# Altium schematic sheet 3_PressureSensor.SchDoc(3_PressureSensor)
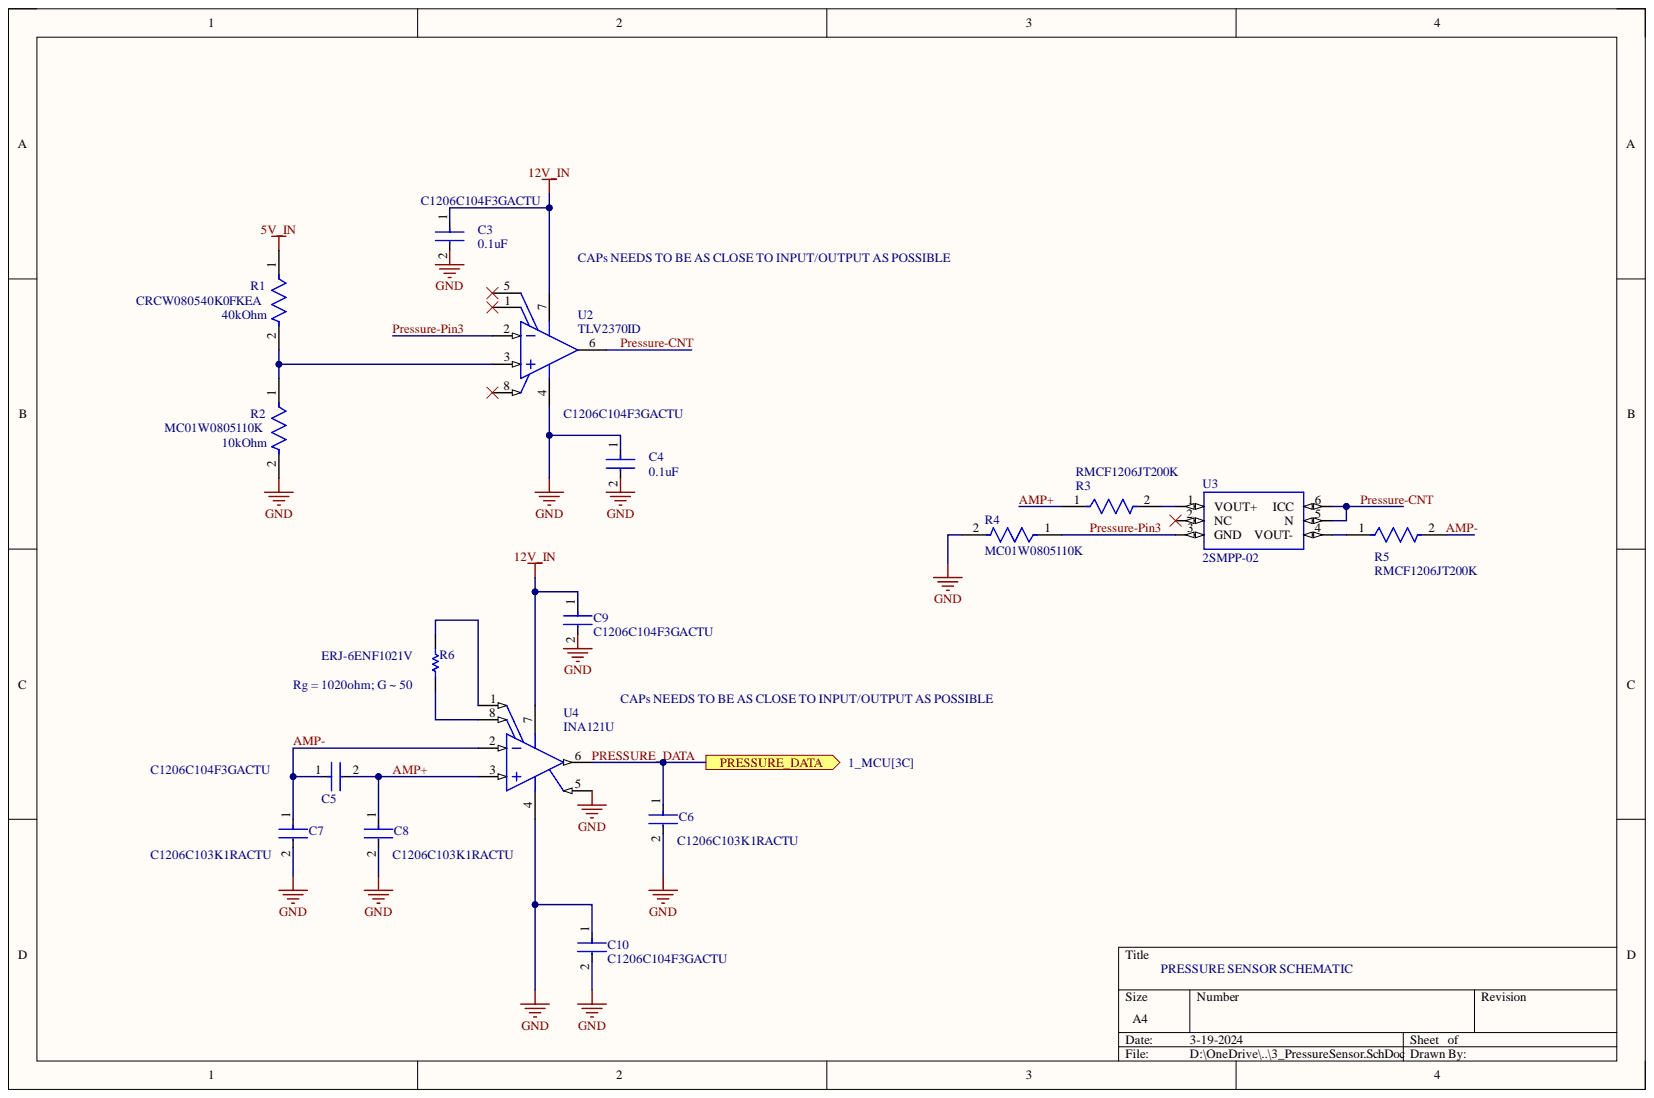
[diagram p0: 3_PressureSensor.SchDoc]

## Parts
C3  C1206C104F3GACTU  [FP-C1206C-EB-MFG]
C4  C1206C104F3GACTU  [FP-C1206C-EB-MFG]
C5  C1206C104F3GACTU  [FP-C1206C-EB-MFG]
C6  C1206C103K1RACTU  [FP-C1206C-EB-MFG]
C7  C1206C103K1RACTU  [FP-C1206C-EB-MFG]
C8  C1206C103K1RACTU  [FP-C1206C-EB-MFG]
C9  C1206C104F3GACTU  [FP-C1206C-EB-MFG]
C10  C1206C104F3GACTU  [FP-C1206C-EB-MFG]
R1  CRCW080540K0FKEA  [FP-CRCW0805-e3-MFG]
R2  MC01W0805110K  [FP-MC01W0805-IPC_C]
R3  RMCF1206JT200K  [FP-RMCF1206-IPC_C]
R4  MC01W0805110K  [FP-MC01W0805-IPC_C]
R5  RMCF1206JT200K  [FP-RMCF1206-IPC_C]
R6  ERJ-6ENF1021V  [RESC2013X70X40ML20T20]
U2  TLV2370ID  [D0008A_L]
U3  2SMPP-02  [2SMPP_PAC]
U4  INA121U  [D0008A_M]

## Ports
PRESSURE_DATA

## Nets
5V_IN: R1.1
12V_IN: C3.1 C9.1 U2.7 U4.7
GND: C3.2 C4.1 C4.2 C6.2 C7.2 C8.2 C9.2 C10.1 C10.2 R2.2 R4.2 U2.4 U4.4 U4.5
AMP+: C5.2 C8.1 R3.1 U4.3
AMP-: C5.1 C7.1 R5.2 U4.2
Pressure-CNT: U2.6 U3.5(N) U3.6(ICC)
Pressure-Pin3: R4.1 U2.2 U3.3(GND)
PRESSURE_DATA: C6.1 U4.6 ; port PRESSURE_DATA
NetR1_2: R1.2 R2.1 U2.3
NetR3_2: R3.2 U3.1(VOUT+)
NetR5_1: R5.1 U3.4(VOUT-)
NetR6_1: R6.1 U4.1
NetR6_2: R6.2 U4.8

Not connected: U2 1 5 8; U3 2(NC)

## Library data of the parts
C3-C5 C9 C10 = CMP-1037-04186-2: CAP CER 0.1UF 25V C0G/NP0 1206; Manufacturer: KEMET; Automotive Grade: Grade 0; Capacitance: 0.10 μF; Material: Ceramic; Temperature Characteristic: C0G; Temperature Coefficient: 15%; Tolerance: 0.01; Value: 0.10 μF; Automotive: Yes; Category: Cap; Component Kind: Standard; ComponentLink2Description: Datasheet; ComponentLink2URL: https://content.kemet.com/datasheets/KEM_C1007_X8R_ULTRA_150C_SMD.pdf; Device Class L1: Passive Components; Device Class L2: Capacitors; Device Class L3: Ceramic Capacitors; Digikey Description: CAP CER 0.1UF 25V C0G/NP0 1206; Height: 0.88mm; Ipc Land Pattern Name: CAPC320160X78; Lead Free: Yes; Library Name: Altium Content Vault; Manufacturer Part Number: C1206C104F3GACTU; Mouser Description: Multilayer Ceramic Capacitors MLCC - SMD/SMT 25volts 0.1uF 1% C0G; Octopart: https://octopart.com/c1206c104f3gactu-kemet-13149870; Package: C1206C-EB; RoHS: TRUE; Temperature: -55°C to +150°C; Temperature Range High: +150°C; Temperature Range Low: -55°C; Voltage Rating: 25 V
C6-C8 = CMP-03020-021723-1: Cap Ceramic 0.01uF 100V X7R 10% SMD 1206 125°C Plastic T/R; Manufacturer: KEMET; Automotive Grade: Grade 0; Capacitance: 10,000 pF; Temperature Coefficient: 15%; Tolerance: 0.1; Value: 10,000 pF; Automotive: Yes; Category: Cap; Component Kind: Standard; ComponentLink2Description: Datasheet; ComponentLink2URL: https://content.kemet.com/datasheets/KEM_C1007_X8R_ULTRA_150C_SMD.pdf; Device Class L1: Passive Components; Device Class L2: Capacitors; Device Class L3: Ceramic Capacitors; Digikey Description: Cap Ceramic 0.01uF 100V X7R 10% SMD 1206 125°C Plastic T/R; Height: 0.88mm; Ipc Land Pattern Name: CAPC320160X78; Lead Free: Yes; Library Name: Altium Content Vault; Manufacturer Part Number: C1206C103K1RACTU; Material: Ceramic; Mouser Description: Multilayer Ceramic Capacitors MLCC - SMD/SMT 100volts 10000pF X7R 10%; Octopart: https://octopart.com/c1206c103k1ractu-kemet-117927; Package: C1206C-EB; RoHS: TRUE; Temperature: -55°C to +150°C; Temperature Characteristic: X7R; Temperature Range High: +150°C; Temperature Range Low: -55°C; Voltage Rating: 100 V
R1 = CMP-02407-003498-1: RES Thick Film, 40kΩ, 1%, 0.125W, 100ppm/°C, 0805; Max Power Dissipation: 125mW; Resistance: 40kR; Case/Package: 0805; Component Kind: Standard; Composition: Thick Film; Features: Automotive AEC-Q200; Height: 0.02inch; Lead Free: Lead Free; Length: 0.079inch; Library Name: Altium Content Vault; Max Operating Temperature: 155°C; Min Operating Temperature: -55°C; Number of Terminations: 2; Package Quantity: 5000; Packaging: Tape and Reel; Temperature Coefficient: 100ppm/°C; Tolerance: 1%; Width: 0.049inch
R2 R4 = CMP-12256-000003-1: Resistor, 10K, 0.1W, 1%, 0805, Reel; Power Rating: 100mW; Resistance: 10kR; Temperature Coefficient: 100ppm/°C; Voltage Rating: 150V; Case Code (Imperial): 0805; Case Code (Metric): 2012; Case/Package: 0805; Component Kind: Standard; Composition: Thick Film; Library Name: Altium Content Vault; Max Operating Temperature: 155°C; Min Operating Temperature: -55°C; Number of Pins: 2; Packaging: Cut Tape; REACH SVHC: No SVHC; Tolerance: 1%; Voltage Rating (DC): 150V; Width: 1.25mm
R3 R5 = CMP-26527-005036-1: 200kΩ ±5% 0.25W 1206 Thick Film Chip Resistor AEC-Q200 compliant; Max Power Dissipation: 250mW; Resistance: 200kR; Temperature Coefficient: 200ppm/°C; Case Code (Imperial): 1206; Case Code (Metric): 3216; Case/Package: 1206; Component Kind: Standard; Construction: Rectangular; Contact Plating: Tin; Depth: 1.6mm; Features: Automotive AEC-Q200; Height: 0.028inch; Lead Free: Lead Free; Length: 0.126inch; Library Name: Altium Content Vault; Max Operating Temperature: 155°C; Military Standard: Not; Min Operating Temperature: -55°C; Mount: Surface Mount; Number of Terminations: 2; Packaging: Tape and Reel; Power Rating: 250mW; Radiation Hardening: No; Resistor Type: Thick Film; RoHS Compliant: Yes; Series: RMCF; Size Code: 1206; Tolerance: 5%; Width: 0.063inch
R6 = CMP-2001-04380-1: Manufacturer: Panasonic; Component Kind: Standard; Library Name: Altium Content Vault; Part Number: ERJ-6ENF1021V
U2 = CMP-0272-03086-3: 550 uA/Ch, 3 MHz, RRIO Operational Amplifier with Shutdown, 2.7 to 16 V, -40 to 125 degC, 8-pin SOIC (D8), Green (RoHS & no Sb/Br); Manufacturer: Texas Instruments; CMRR(Min)(dB): 50; GBW(Typ)(MHz): 3; IIB(Max)(pA): 60; Iq per channel(Max)(mA): 0.9; Number of Channels: 1; Offset Drift(Typ)(uV/C): 2; Packing: TUBE; Rating: Catalog; Slew Rate(Typ)(V/us): 2.4; Sub Family: Low Supply Voltage Amplifier (<=2.7V), Precision Amplifier; Total Supply Voltage(Max)(+5V is 5, +/-5V is 10): 16; Total Supply Voltage(Min)(+5V is 5, +/-5V is 10): 2.7; Vio (25C)(Max)(mV): 4.5; Code_JEDEC: MS-012; Component Kind: Standard; ComponentLink1Description: Manufacturer URL; ComponentLink1URL: http://www.ti.com/; ComponentLink2Description: Datasheet; ComponentLink2URL: http://www.ti.com/lit/ds/symlink/tlv2370.pdf; ComponentLink3Description: Package Specification; ComponentLink3URL: http://www.ti.com/litv/pdf/msoi002j; DatasheetVersion: SLOS270D; Eco-Plan: Green (RoHS & no Sb/Br); Library Name: Altium Content Vault; Mounting Technology: Surface Mount; PackageDescription: 8-Pin Plastic Small Outline, Body 4.9 x 3.9 mm, Pitch 1.27 mm; PackageReference: D0008A; PackageVersion: 4040047-3/M, 06/2011; PartNumber: TLV2370ID
U3 = CMP-007-000000-1: Pressure Sensor 5.37PSI _37kPa_ Vented Gauge Male - 0.1 _2.59mm_ Tube 0 mV ~ 31 mV 6-SMD Module; MF: Omron; Availability: Unavailable; Component Kind: Standard; Component Type: Standard; Design Item ID: 2SMPP-02; Library Name: McMaster University; MP: 2SMPP-02; Package: SMD-6 Omron; Pin Count: 6; Price: None; SnapEDA_Link: https://www.snapeda.com/parts/2SMPP-02/Omron%20Electronics%20Inc-EMC%20Div/view-part/384453/?ref=snap; Source: SnapEDAsch.SchLib
U4 = CMP-0992-00043-2: FET-Input, Low Power Instrumentation Amplifier, -40 to 85 degC, 8-pin SOIC (D8), Green (RoHS & no Sb/Br); Manufacturer: Texas Instruments; CMRR(Min)(dB): 96; Gain(V/V): 1 to 10000; Input Bias Current (+/-)(Max)(nA): 0.05; Input Offset (+/-)(Max)(uV): 500; Input Offset Drift (+/-)(Max)(uV/Degrees Celsius): 5; Noise at 1kHz(Typ)(nV/rt(Hz)): 20; Non-Linearity (+/-)(Max)(%): 0.005; Number of Channels: 1; Output Offset (+/-)(Max)(uV): 500/G; Output Offset Drift (+/-)(Max)(uV/Degrees Celsius): 20/G; Quiescent Current (+/-)(Typ)(mA): 0.45; SubFamily: Dual Supply; Vs(Max)(V): 36; Vs(Min)(V): 4.5; Code_JEDEC: MS-012; Component Kind: Standard; ComponentLink1Description: Manufacturer URL; ComponentLink1URL: http://www.ti.com/; ComponentLink2Description: Datasheet; ComponentLink2URL: http://www.ti.com/general/docs/lit/getliterature.tsp?genericPartNumber=INA121&fileType=pdf; ComponentLink3Description: Package Specification; ComponentLink3URL: http://www.ti.com/litv/pdf/msoi002j; DatasheetVersion: SBOS078; Eco-Plan: Green (RoHS & no Sb/Br); Library Name: Altium Content Vault; Mounting Technology: Surface Mount; PackageDescription: 8-Pin Plastic Small Outline, Body 4.9 x 3.9 mm, Pitch 1.27 mm; PackageReference: D0008A; PackageVersion: 4040047-3/M, 06/2011; PartNumber: INA121U
